# Altium schematic sheet Connectors.SchDoc(Connectors)
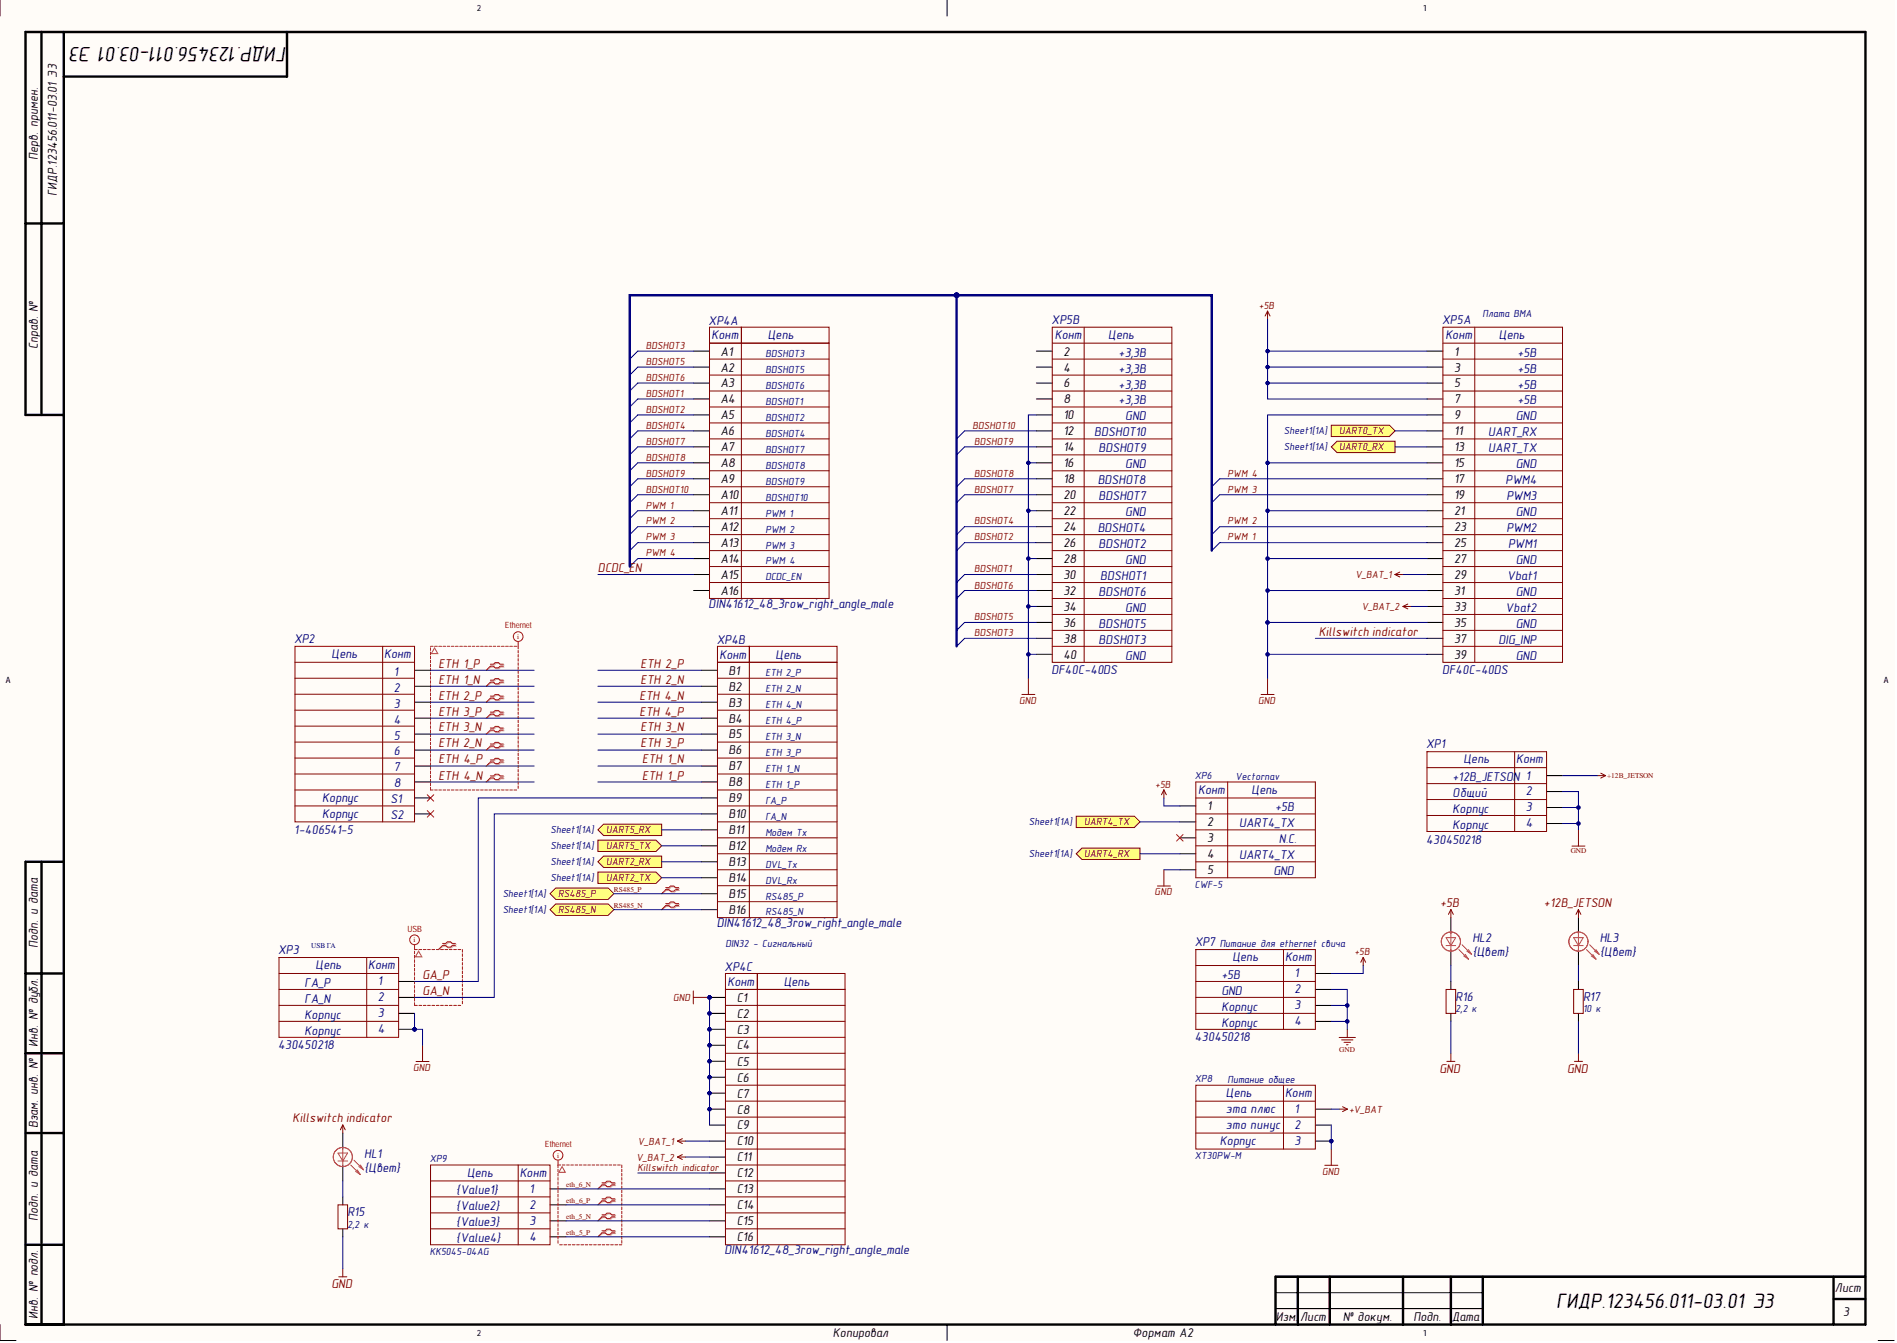
[diagram p0: Connectors.SchDoc]

## Parts
HL1  Color: {Цвет}  [0805D]  (hidden comment: LED)
HL2  Color: {Цвет}  [0805D]  (hidden comment: LED)
HL3  Color: {Цвет}  [0805D]  (hidden comment: LED)
R15  value 2,2 к  [0603R]  (hidden comment: Resistor)
R16  value 2,2 к  [0603R]  (hidden comment: Resistor)
R17  value 10 к  [0603R]  (hidden comment: Resistor)
XP1  430450218  Value1: +12В_JETSON  Value2: Общий  Value3: Корпус  [Molex_430450218]
XP2  1-406541-5  [1-406541-5]
XP3  430450218  Parameter 1: USB ГА  Value1: ГА_P  Value2: ГА_N  Value3: Корпус  [Molex_430450218]
XP4  DIN41612_48_3row_right_angle_male  [DIN41612_48_3row_right_angle_male]  (parts A,B,C)
XP5  DF40C-40DS  [DF40C-40DS]  (parts A,B)
XP6  CWF-5  Value1: +5В  Value2: UART4_TX  Value3: N.C.  Value5: GND  [CWF-5]
XP7  430450218  Value1: +5В  Value2: GND  Value3: Корпус  [Molex_430450218]
XP8  XT30PW-M  Value1: эта плюс  Value2: это пинус  Value3: Корпус  [XT30PW-M]
XP9  KK5045-04AG  Value1: {Value1}  Value2: {Value2}  Value3: {Value3}  Value4: {Value4}  [KK5045-04AG]

## Ports
RS485_N, RS485_P, UART0_RX, UART0_TX, UART2_RX, UART2_TX, UART4_RX, UART4_TX, UART5_RX, UART5_TX

## Nets
+5В: HL2.1 XP5.1 XP5.3 XP5.5 XP5.7 XP6.1 XP7.1
+12В_JETSON: HL3.1 XP1.1
+V_BAT: XP8.P
GND: R15.1 R16.1 R17.1 XP1.0 XP1.2 XP3.0 XP4.C1 XP4.C2 XP4.C3 XP4.C4 XP4.C5 XP4.C6 XP4.C7 XP4.C8 XP4.C9 XP5.9 XP5.10 XP5.15 XP5.16 XP5.21 XP5.22 XP5.27 XP5.28 XP5.31 XP5.34 XP5.35 XP5.39 XP5.40 XP6.5 XP7.0 XP7.2 XP8.0 XP8.N
V_BAT_1: XP4.C10 XP5.29
V_BAT_2: XP4.C11 XP5.33
BDSHOT1: XP4.A4 XP5.30
BDSHOT2: XP4.A5 XP5.26
BDSHOT3: XP4.A1 XP5.38
BDSHOT4: XP4.A6 XP5.24
BDSHOT5: XP4.A2 XP5.36
BDSHOT6: XP4.A3 XP5.32
BDSHOT7: XP4.A7 XP5.20
BDSHOT8: XP4.A8 XP5.18
BDSHOT9: XP4.A9 XP5.14
BDSHOT10: XP4.A10 XP5.12
DCDC_EN: XP4.A15
ETH 1_N: XP2.2 XP4.B7
ETH 1_P: XP2.1 XP4.B8
ETH 2_N: XP2.6 XP4.B2
ETH 2_P: XP2.3 XP4.B1
ETH 3_N: XP2.5 XP4.B5
ETH 3_P: XP2.4 XP4.B6
ETH 4_N: XP2.8 XP4.B3
ETH 4_P: XP2.7 XP4.B4
eth_5_N: XP4.C15 XP9.3
eth_5_P: XP4.C16 XP9.4
eth_6_N: XP4.C13 XP9.1
eth_6_P: XP4.C14 XP9.2
GA_N: XP3.2 XP4.B10
GA_P: XP3.1 XP4.B9
Killswitch indicator: HL1.1 XP4.C12 XP5.37
PWM 1: XP4.A11 XP5.25
PWM 2: XP4.A12 XP5.23
PWM 3: XP4.A13 XP5.19
PWM 4: XP4.A14 XP5.17
RS485_N: XP4.B16 ; port RS485_N
RS485_P: XP4.B15 ; port RS485_P
UART0_RX: XP5.13 ; port UART0_RX
UART0_TX: XP5.11 ; port UART0_TX
UART2_RX: XP4.B13 ; port UART2_RX
UART2_TX: XP4.B14 ; port UART2_TX
UART4_RX: XP6.4 ; port UART4_RX
UART4_TX: XP6.2 ; port UART4_TX
UART5_RX: XP4.B11 ; port UART5_RX
UART5_TX: XP4.B12 ; port UART5_TX
NetHL1_2: HL1.2 R15.2
NetHL2_2: HL2.2 R16.2
NetHL3_2: HL3.2 R17.2

Not connected: XP2 MH1(S1) MH2(S2); XP4 A16; XP5 2 4 6 8; XP6 3

## Library data of the parts
HL1-HL3 = LED: Светодиод; Database Table Name: Other$; Component Kind: Standard; Library Name: Hydrolib.DbLib; Part Number: LED
R15 R16 = Resistor_2.2k_1_0603: Резистор номиналом 2,2 кОм 1%; Database Table Name: Resistors$; Component Kind: Standard; Library Name: Hydrolib.DbLib; Part Number: Resistor_2.2k_1_0603; Tolerance: 1%
R17 = Resistor_10k_1_0603: Резистор номиналом 10 кОм 1%; Database Table Name: Resistors$; Component Kind: Standard; Library Name: Hydrolib.DbLib; Part Number: Resistor_10k_1_0603; Tolerance: 1%
XP1 XP3 XP7 = Molex_430450218: Разъем типа провод-плата, 3 мм, 2 контакт(-ов), Штыревой Разъем, Серия Micro-Fit для поверхностного монтажа; Database Table Name: Connectors$; Component Kind: Standard; Library Name: Hydrolib.DbLib; Part Number: Molex_430450218; Value4: Корпус
XP2 = 1-406541-5-RJ45-8p8c: Модульный разъем, Cat5, RJ45, Гнездо, 8 контакт(-ов), 8 вывод(-ов), 1 порт(-ов); Database Table Name: Connectors$; System: Connector; Автор: glebmih; Component Kind: Standard; Datasheet: https://cdn.promelec.ru/upload/items/2021/01/20/ENG_CD_406541_T.pdf; Library Name: Hydrolib.DbLib; Part Number: 1-406541-5-RJ45-8p8c
XP4 = DIN41612_48_3row_right_angle_male: DIN41612R (DS1120-48M0R13), Разъем (вилка) тип B 48pin (3х16), шаг 2.54мм трехрядный угловой (ряд АВC); Database Table Name: Connectors$; Parameter 1: {Value}; System: Connector; Автор: glebmih; Component Kind: Standard; Datasheet: https://cdn.promelec.ru/upload/datasheet/3/1/DS1120.pdf; Library Name: Hydrolib.DbLib; Parameter 2: {Value}; Parameter 3: {Value}; Parameter 4: {Value}; Parameter 5: {Value}; Parameter 6: {Value}; Parameter 7: {Value}; Parameter 8: {Value}; Parameter 9: {Value}; Parameter 10: {Value}; Parameter 11: {Value}; Parameter 12: {Value}; Parameter 13: {Value}; Parameter 14: {Value}; Parameter 15: {Value}; Parameter 16: {Value}; Part Number: DIN41612_48_3row_right_angle_male
XP5 = DF40C-40DS: Thruster control PCB; Component Kind: Standard; Library Name: VMA.SchLib
XP6 = CWF-5: 5-пиновый разъем с ключом; Database Table Name: Connectors$; System: Connector; Component Kind: Standard; Library Name: Hydrolib.DbLib; Part Number: CWF-5; Value4: UART4_TX
XP8 = XT30PW-M: 30 А (15 продолжительно), 2 контакта разъем угловой отец; Database Table Name: Connectors$; System: Connector; Component Kind: Standard; Library Name: Hydrolib.DbLib; Part Number: XT30PW-M
XP9 = KK5045-04AG: Разъем типа провод-плата, 2.5 мм, 4 контакта; Database Table Name: Connectors$; Parameter 1: {Value}; System: Connector; Component Kind: Standard; Library Name: Hydrolib.DbLib; Part Number: KK5045-04AG
